# Altium schematic sheet Power.SchDoc(Power)
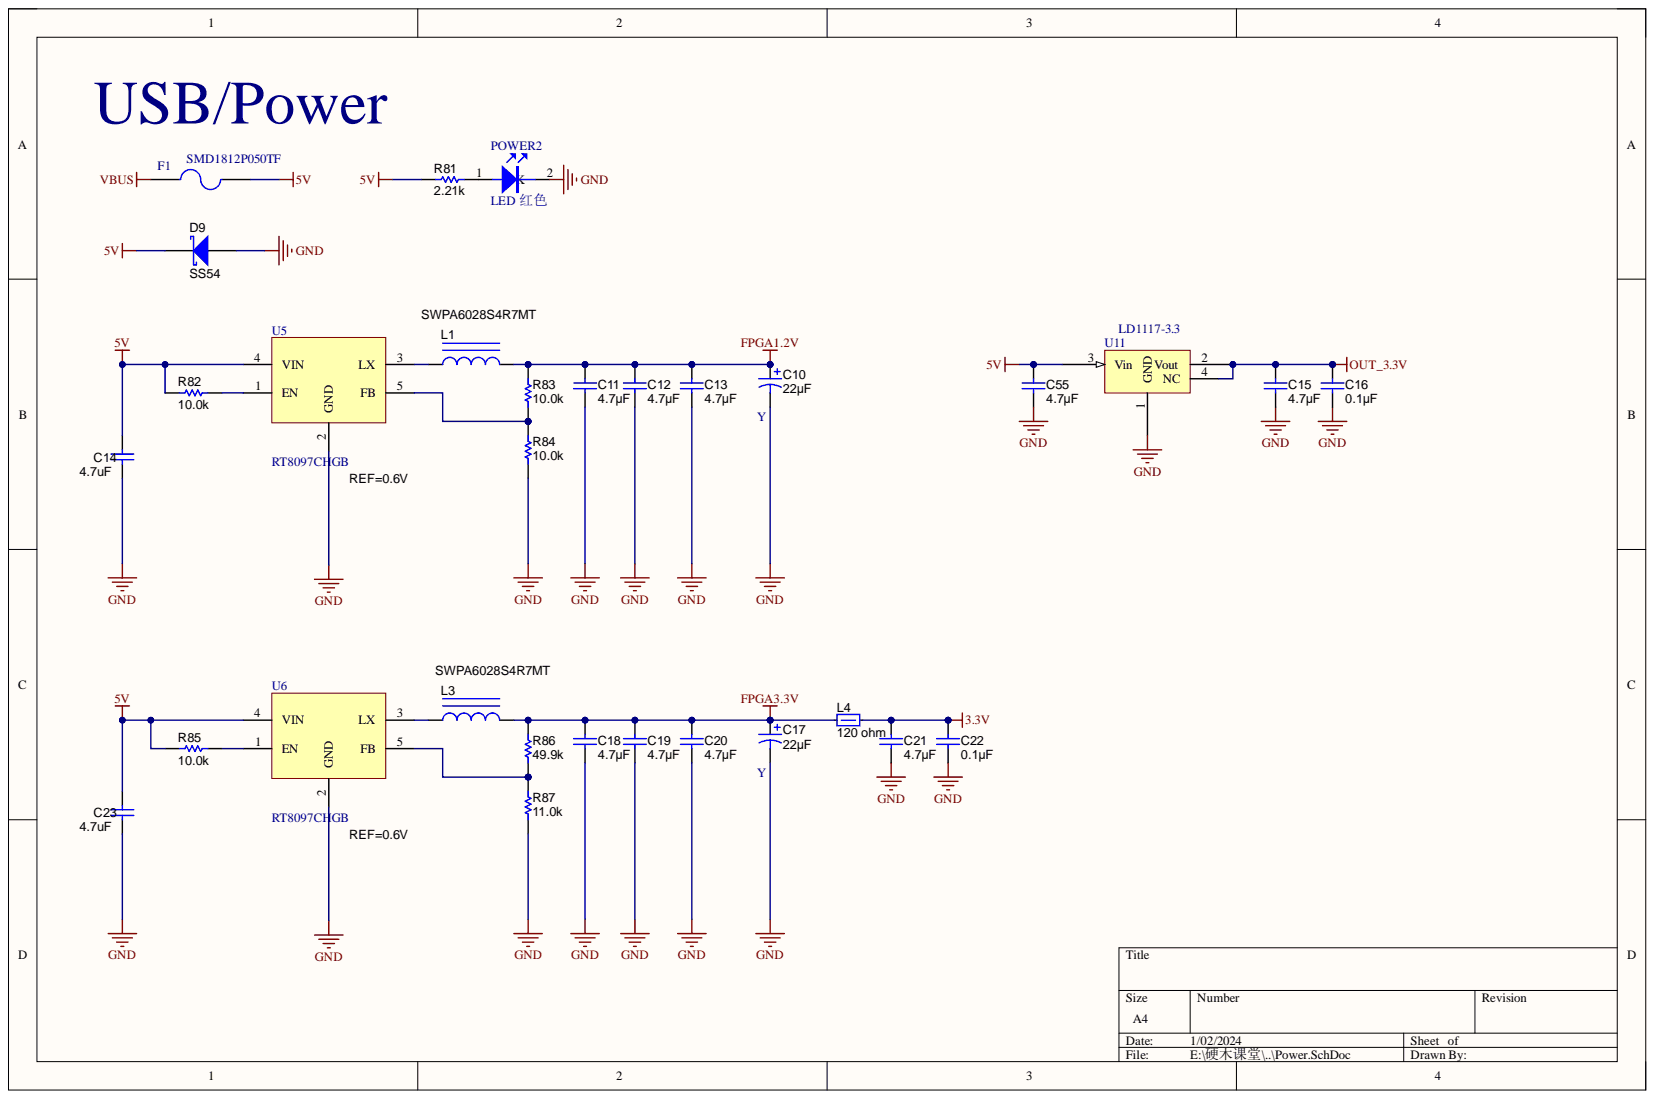
[diagram p0: Power.SchDoc]

## Parts
C10  Y  ValueDisplayed: 22µF  [6032-28]
C11  ValueDisplayed: 4.7µF  [0805_HV]  (hidden comment: GRM21BC81C475KA88L)
C12  ValueDisplayed: 4.7µF  [0805_HV]  (hidden comment: GRM21BC81C475KA88L)
C13  ValueDisplayed: 4.7µF  [0805_HV]  (hidden comment: GRM21BC81C475KA88L)
C14  value 4.7uF  [0805_HV]  (hidden comment: GRM21BC81C475KA88L)
C15  ValueDisplayed: 4.7µF  [0805_HV]  (hidden comment: GRM21BC81C475KA88L)
C16  ValueDisplayed: 0.1µF  [0402]  (hidden comment: C1005X7R1H104M)
C17  Y  ValueDisplayed: 22µF  [6032-28]
C18  ValueDisplayed: 4.7µF  [0805_HV]  (hidden comment: GRM21BC81C475KA88L)
C19  ValueDisplayed: 4.7µF  [0805_HV]  (hidden comment: GRM21BC81C475KA88L)
C20  ValueDisplayed: 4.7µF  [0805_HV]  (hidden comment: GRM21BC81C475KA88L)
C21  ValueDisplayed: 4.7µF  [0805_HV]  (hidden comment: GRM21BC81C475KA88L)
C22  ValueDisplayed: 0.1µF  [0402]  (hidden comment: C1005X7R1H104M)
C23  value 4.7uF  [0805_HV]  (hidden comment: GRM21BC81C475KA88L)
C55  ValueDisplayed: 4.7µF  [0805_HV]  (hidden comment: GRM21BC81C475KA88L)
D9  SS54  [SMB]
F1  SMD1812P050TF  [1812]
L1  SWPA6028S4R7MT  [LPS6225]
L3  SWPA6028S4R7MT  [LPS6225]
L4  value 120 ohm  [0805_HV]  (hidden comment: GZ2012V121TF)
POWER2  LED 红色  [LED_LTST-C170]
R81  value 2.21k  [0402]  (hidden comment: CRCW04022K21FKED)
R82  value 10.0k  [0402]  (hidden comment: CRCW040210K0FKED)
R83  value 10.0k  [0402]  (hidden comment: CRCW040210K0FKED)
R84  value 10.0k  [0402]  (hidden comment: CRCW040210K0FKED)
R85  value 10.0k  [0402]  (hidden comment: CRCW040210K0FKED)
R86  value 49.9k  [0402]  (hidden comment: CRCW040249K9FKED)
R87  value 11.0k  [0402]  (hidden comment: CRCW040211K0FKED)
U5  RT8097CHGB  [DBV0005A_N]
U6  RT8097CHGB  [DBV0005A_N]
U11  LD1117-3.3  [SOT223-L]

## Nets
3.3V: C21.1 C22.1 L4.2
5V: C14.1 C23.1 C55.1 D9.1 F1.2 R81.2 R82.2 R85.2 U5.4(VIN) U6.4(VIN) U11.3(Vin)
FPGA1.2V: C10.1 C11.1 C12.1 C13.1 L1.2 R83.2
FPGA3.3V: C17.1 C18.1 C19.1 C20.1 L3.2 L4.1 R86.2
GND: C10.2 C11.2 C12.2 C13.2 C14.2 C15.2 C16.2 C17.2 C18.2 C19.2 C20.2 C21.2 C22.2 C23.2 C55.2 D9.2 POWER2.2 R84.1 R87.1 U5.2(GND) U6.2(GND) U11.1(GND)
OUT_3.3V: C15.1 C16.1 U11.2(Vout) U11.4(NC)
VBUS: F1.1
NetL1_1: L1.1 U5.3(LX)
NetL3_1: L3.1 U6.3(LX)
NetPOWER2_1: POWER2.1 R81.1
NetR82_1: R82.1 U5.1(EN)
NetR83_1: R83.1 R84.2 U5.5(FB)
NetR85_1: R85.1 U6.1(EN)
NetR86_1: R86.1 R87.2 U6.5(FB)

## Library data of the parts
C10 C17 = 293D226X9016C2TE3: CAP, TA, 22 µF, 16 V, +/- 10%, 1.8 ohm, SMD; Manufacturer: Vishay-Sprague; Cap_Case: C; Cap_F: 0.000022; CompType: Capacitor; ESR_ohm: 1.8; IRMS_A: 0.28; TaMax_C: 125; TaMin_C: -55; Tolerance_%: 10; U/M: EA; Value: 22uF; Value1: 1.8 ohm; Value2: 16V; VDC_V: 16; Component Kind: Standard; ComponentLink1Description: Datasheet link; ComponentLink1URL: http://www.vishay.com/docs/40002/293d.pdf; IGNORE: Y; LatestRevisionDate: 2015-03-19; LatestRevisionNote: Updated supplier links; Library Name: Capacitor_Tantalum.IntLib; MountType: SMT; PackageReference: 6032-28; PartNumber: 293D226X9016C2TE3; Polarized: Y; RoHS: Y; Series: 293D; Signal Integrity: Cap; Simulation: Cap; Supplier 1: Digi-Key; Supplier 2: Mouser; Supplier 3: Farnell; Supplier Part Number 1: 718-1066-1-ND; Supplier Part Number 2: 74-293D226X9016C2TE3; Supplier Part Number 3: 1754187; SymbolVersion: 2008-07-09; Technology: TA; Publisher: Texas Instruments
C11-C13 C15 C18-C21 C55 = GRM21BC81C475KA88L: CAP, CERM, 4.7 µF, 16 V, +/- 10%, X6S, 0805; Manufacturer: MuRata; Cap_Case: 0805; Cap_F: 0.0000047; CompType: Capacitor; Polarized: N; TaMax_C: 105; TaMin_C: -55; Tolerance_%: 10; U/M: EA; Value: 4.7uF; Value1: Unknown; Value2: 16V; VDC_V: 16; Component Kind: Standard; ComponentLink1Description: Datasheet link; ComponentLink1URL: http://search.murata.co.jp/Ceramy/PnsearchViewAction.do?HnOFG=ON&HnNFG=ON&SEL_CNT=50&sLang=en&sKey=GRM21BC81C475KA88L&x=9&y=9&search=startwith; LatestRevisionDate: 2015-05-02; Library Name: Capacitor_Ceramic.IntLib; MountType: SMT; PackageReference: 0805; PartNumber: GRM21BC81C475KA88L; Published: 2008-06-19; RoHS: Y; Signal Integrity: Cap; Simulation: Cap; Supplier 1: Digi-Key; Supplier 2: Mouser; Supplier 3: Farnell; Supplier Part Number 1: GRM21BC81C475KA88L-ND; Supplier Part Number 2: 81-GRM21BC81C475KA8L; Supplier Part Number 3: 1889279; SymbolVersion: 2008-07-09; Technology: Ceramic; TempCo: X6S; Publisher: Texas Instruments
C14 C23 = GRM21BC81C475KA88L: CAP, CERM, 4.7 µF, 16 V, +/- 10%, X6S, 0805; Manufacturer: MuRata; Cap_Case: 0805; Cap_F: 0.0000047; CompType: Capacitor; Polarized: N; TaMax_C: 105; TaMin_C: -55; Tolerance_%: 10; U/M: EA; Value1: Unknown; Value2: 16V; VDC_V: 16; Component Kind: Standard; ComponentLink1Description: Datasheet link; ComponentLink1URL: http://search.murata.co.jp/Ceramy/PnsearchViewAction.do?HnOFG=ON&HnNFG=ON&SEL_CNT=50&sLang=en&sKey=GRM21BC81C475KA88L&x=9&y=9&search=startwith; LatestRevisionDate: 2015-05-02; Library Name: Capacitor_Ceramic.IntLib; MountType: SMT; PackageReference: 0805; PartNumber: GRM21BC81C475KA88L; Published: 2008-06-19; RoHS: Y; Signal Integrity: Cap; Simulation: Cap; Supplier 1: Digi-Key; Supplier 2: Mouser; Supplier 3: Farnell; Supplier Part Number 1: GRM21BC81C475KA88L-ND; Supplier Part Number 2: 81-GRM21BC81C475KA8L; Supplier Part Number 3: 1889279; SymbolVersion: 2008-07-09; Technology: Ceramic; TempCo: X6S; ValueDisplayed: 4.7uF; Publisher: Texas Instruments
C16 C22 = C1005X7R1H104M: CAP, CERM, 0.1 µF, 50 V, +/- 20%, X7R, 0402; Manufacturer: TDK; Cap_F: 0.0000001; CompType: Capacitor; Polarized: N; TaMax_C: 125; TaMin_C: -55; Tolerance_%: 20; U/M: EA; Value: 0.1uF; Value1: Unknown; Value2: 50V; VDC_V: 50; Cap_Case: 0402; Component Kind: Standard; ComponentLink1Description: Datasheet link; LatestRevisionDate: 2015-05-02; Library Name: Capacitor_Ceramic.IntLib; MountType: SMT; PackageReference: 0402; PartNumber: C1005X7R1H104M; Published: 2008-06-19; RoHS: Y; Signal Integrity: Cap; Simulation: Cap; Supplier 1: Digi-Key; Supplier 2: Farnell; Supplier Part Number 1: 445-5933-1-ND; Supplier Part Number 2: 1907278; SymbolVersion: 2008-07-09; Technology: Ceramic; TempCo: X7R; Publisher: Texas Instruments
D9 = B340B-13-F: Diode, Schottky, 40 V, 3A, SMB; Manufacturer: Diodes Inc.; Io_A: 3; RJC_CperW: 25; TJmax_C: 125; U/M: EA; Value: 40V; Value2: 0.5V; Vf_V: 0.5; Vr_V: 40; Component Kind: Standard; ComponentLink1Description: Datasheet link; ComponentLink1URL: http://www.diodes.com/datasheets/ds30924.pdf; CompType: Diode; LatestRevisionDate: 2015-04-30; Library Name: Diode.IntLib; MountType: SMT; PackageReference: SMB; PartNumber: SS54; Published: 2008-06-26; RoHS: Y; Signal Integrity: Diode; Simulation: DI_B340B; Supplier 1: Digi-Key; Supplier 2: Mouser; Supplier 3: Farnell; Supplier Part Number 1: B340B-FDICT-ND; Supplier Part Number 2: 621-B340B-F; Supplier Part Number 3: 1843677; SymbolVersion: 2008-12-08; Type: Schottky; Value1: 3A; Publisher: Texas Instruments
F1 = Fuse 2: 0.5A自恢复贴片保险丝; Component Kind: Standard; LatestRevisionDate: 17-Jul-2002; LatestRevisionNote: Re-released for DXP Platform.; Library Name: Miscellaneous Devices.IntLib; PackageDescription: Thru-Hole; 2 Leads; PackageReference: PIN-W2/E2.8; Published: 8-Jun-2000; Publisher: Altium Limited
L1 L3 = LPS6225-103MLB: Inductor, Shielded Drum Core, Ferrite, 4.7µH, 1.3 A, 0.1 ohm, SMD; Manufacturer: Coilcraft; DCR_ohm: 0.1; Imax_A: 1.3; Ind_H: 10.0E-6; Irms: 1.3A @ 40C rise; Isat: 2.2A @ 30% inductance drop; Value: 10uH; Value1: 0.1 ohm; Value2: 1.3A; Component Kind: Standard; ComponentLink1Description: Datasheet link; ComponentLink1URL: http://www.coilcraft.com/pdfs/lps6225.pdf; CompType: Inductor; LatestRevisionDate: 2015-04-27; Library Name: Inductor_Coilcraft.IntLib; Material: Ferrite; MountType: SMT; PackageReference: LPS6225; PartNumber: SWPA6028S4R7MT; Published: 2008-06-26; RoHS: Y; Signal Integrity: Inductor; Simulation: Inductor; SymbolVersion: 2008-07-23; Technology: Shielded Drum Core; ValueDisplayed: 4.7µH; Publisher: Texas Instruments
L4 = BLM21BD121SN1D: Ferrite Bead, 120 ohm @ 100 MHz, 0.2 A, 0805; Manufacturer: MuRata; DCR_ohm: 0.25; Imax_A: 0.2; Value1: 0.25 ohm; Value2: 0.2A; ZTyp@100MHz_Ohm: 120; Component Kind: Standard; ComponentLink1Description: Datasheet link; ComponentLink1URL: http://search.murata.co.jp/Ceramy/image/img/PDF/ENG/L0110S0100BLM21B.pdf; CompType: Ferrite Bead; LatestRevisionDate: 2015-05-01; Library Name: FerriteBead.IntLib; MountType: SMT; PackageReference: 0805; PartNumber: GZ2012V121TF; Published: 2014-04-30; RoHS: Y; Supplier 1: Digi-Key; Supplier 2: Mouser; Supplier 3: Farnell; Supplier Part Number 1: 490-1044-1-ND; Supplier Part Number 2: 81-BLM21BD121SN1D; Supplier Part Number 3: 1515637; SymbolVersion: 2009-06-02; Publisher: Texas Instruments
POWER2 = LED: LED 发光二极管; Component Kind: Standard; Library Name: WK_PCB.SchLib
R81 = CRCW04022K21FKED: RES, 2.21 k, 1%, 0.063 W, 0402; Manufacturer: Vishay-Dale; CompType: Resistor; EIA_Code: E96; Power_W: 0.063; Res_ohm: 2210; TempCo: 100 ppm/C; Tolerance_%: 1; U/M: EA; Value2: 0.063W; Value_Code: 2k21; VDC_V: 50; Component Kind: Standard; ComponentLink1Description: Datasheet link; ComponentLink1URL: http://www.vishay.com/docs/20035/dcrcwe3.pdf; LatestRevisionDate: 2015-05-01; Library Name: Resistor_0402.IntLib; MountType: SMT; PackageReference: 0402; PartNumber: CRCW04022K21FKED; Published: 2008-06-26; RoHS: Y; Signal Integrity: Resistor; Simulation: Resistor; Supplier 1: Digi-Key; Supplier 2: Mouser; Supplier 3: Farnell; Supplier Part Number 1: 541-2.21KLCT-ND; Supplier Part Number 2: 71-CRCW0402-2.21K-E3; Supplier Part Number 3: 1469689; SymbolVersion: 2009-05-29; Technology: Thick Film; Value1: 1%; ValueDisplayed: 2.21k; Publisher: Texas Instruments
R82-R85 = CRCW040210K0FKED: RES, 10.0 k, 1%, 0.063 W, 0402; Manufacturer: Vishay-Dale; CompType: Resistor; EIA_Code: E96; Power_W: 0.063; Res_ohm: 10000; TempCo: 100 ppm/C; Tolerance_%: 1; U/M: EA; Value2: 0.063W; Value_Code: 10k0; VDC_V: 50; Component Kind: Standard; ComponentLink1Description: Datasheet link; ComponentLink1URL: http://www.vishay.com/docs/20035/dcrcwe3.pdf; LatestRevisionDate: 2015-05-01; Library Name: Resistor_0402.IntLib; MountType: SMT; PackageReference: 0402; PartNumber: CRCW040210K0FKED; Published: 2008-06-26; RoHS: Y; Signal Integrity: Resistor; Simulation: Resistor; Supplier 1: Digi-Key; Supplier 2: Mouser; Supplier 3: Farnell; Supplier Part Number 1: 541-10.0KLCT-ND; Supplier Part Number 2: 71-CRCW0402-10K-E3; Supplier Part Number 3: 1469669; SymbolVersion: 2009-05-29; Technology: Thick Film; Value1: 1%; ValueDisplayed: 10.0k; Publisher: Texas Instruments
R86 = CRCW040249K9FKED: RES, 49.9 k, 1%, 0.063 W, 0402; Manufacturer: Vishay-Dale; CompType: Resistor; EIA_Code: E96; Power_W: 0.063; Res_ohm: 49900; TempCo: 100 ppm/C; Tolerance_%: 1; U/M: EA; Value2: 0.063W; Value_Code: 49k9; VDC_V: 50; Component Kind: Standard; ComponentLink1Description: Datasheet link; ComponentLink1URL: http://www.vishay.com/docs/20035/dcrcwe3.pdf; LatestRevisionDate: 2015-05-01; Library Name: Resistor_0402.IntLib; MountType: SMT; PackageReference: 0402; PartNumber: CRCW040249K9FKED; Published: 2008-06-26; RoHS: Y; Signal Integrity: Resistor; Simulation: Resistor; Supplier 1: Digi-Key; Supplier 2: Mouser; Supplier 3: Farnell; Supplier Part Number 1: 541-49.9KLCT-ND; Supplier Part Number 2: 71-CRCW0402-49.9K-E3; Supplier Part Number 3: 1469720; SymbolVersion: 2009-05-29; Technology: Thick Film; Value1: 1%; ValueDisplayed: 49.9k; Publisher: Texas Instruments
R87 = CRCW040211K0FKED: RES, 11.0 k, 1%, 0.063 W, 0402; Manufacturer: Vishay-Dale; CompType: Resistor; EIA_Code: E96; Power_W: 0.063; Res_ohm: 11000; TempCo: 100 ppm/C; Tolerance_%: 1; U/M: EA; Value2: 0.063W; Value_Code: 11k0; VDC_V: 50; Component Kind: Standard; ComponentLink1Description: Datasheet link; ComponentLink1URL: http://www.vishay.com/docs/20035/dcrcwe3.pdf; LatestRevisionDate: 2015-05-01; Library Name: Resistor_0402.IntLib; MountType: SMT; PackageReference: 0402; PartNumber: CRCW040211K0FKED; Published: 2008-06-26; RoHS: Y; Signal Integrity: Resistor; Simulation: Resistor; Supplier 1: Digi-Key; Supplier 2: Mouser; Supplier 3: Farnell; Supplier Part Number 1: 541-11.0KLCT-ND; Supplier Part Number 2: 71-CRCW0402-11K-E3; Supplier Part Number 3: 1469673; SymbolVersion: 2009-05-29; Technology: Thick Film; Value1: 1%; ValueDisplayed: 11.0k; Publisher: Texas Instruments
U5 U6 = RT8097: 1MHZ,2A,STEP-DOWM DC/DC; Component Kind: Standard; Partnumber: RT8097CHGB; Library Name: YQPOWER.SchLib
U11 = AMS1117: LDO电源; Component Kind: Standard; Library Name: 控制电路原理图_器件库_V1.0.SCHLIB
